# Altium schematic sheet FEZ-Duino-MIsc.SchDoc(FEZ-Duino-MIsc)
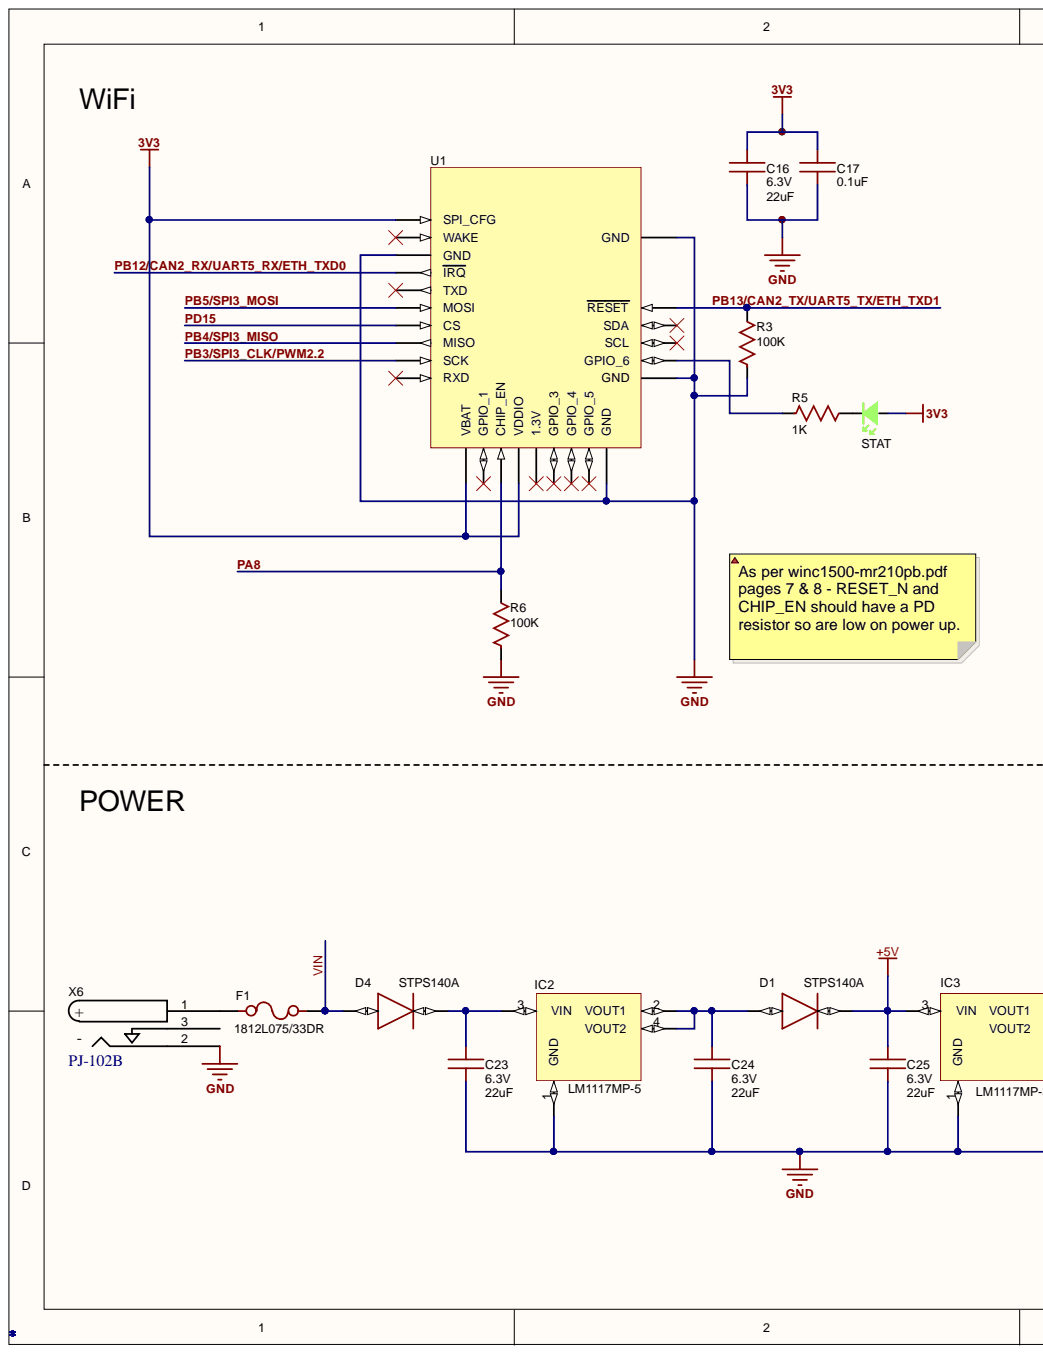
[diagram p0: FEZ-Duino-MIsc.SchDoc, left]
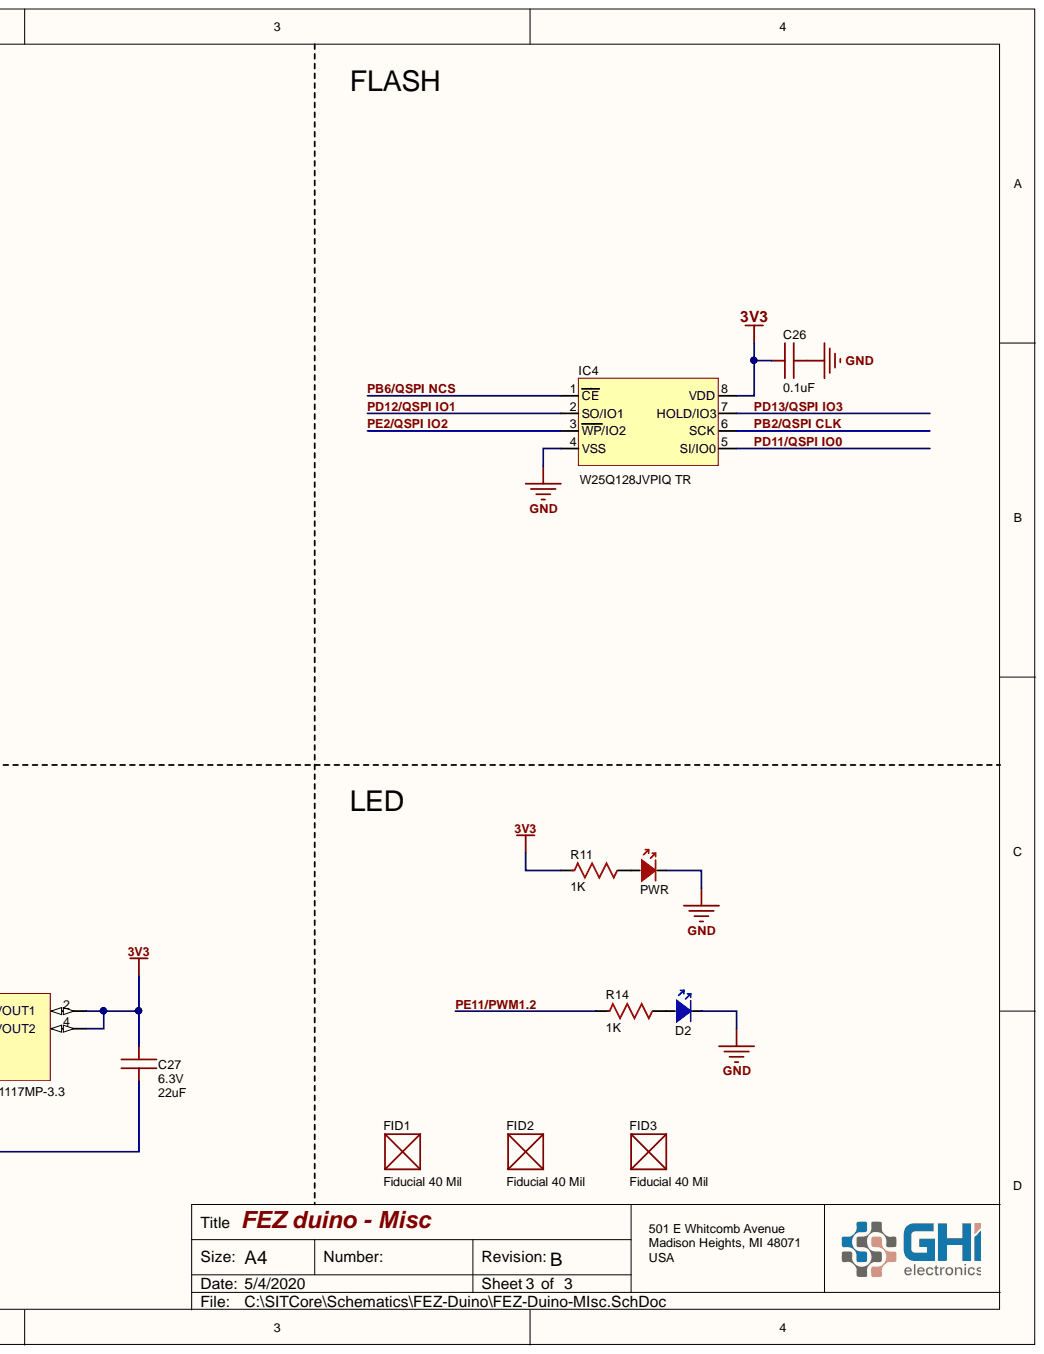
[diagram p1: FEZ-Duino-MIsc.SchDoc, right]

## Parts
C16  value 22uF  Voltage: 6.3V  [C0805 L]  (hidden comment: 0805 Cap 22uF)
C17  value 0.1uF  [C0603 (1608 Metric)]  (hidden comment: 0603 Cap 0.1uF)
C23  value 22uF  Voltage: 6.3V  [C0805 L]  (hidden comment: 0805 Cap 22uF)
C24  value 22uF  Voltage: 6.3V  [C0805 L]  (hidden comment: 0805 Cap 22uF)
C25  value 22uF  Voltage: 6.3V  [C0805 L]  (hidden comment: 0805 Cap 22uF)
C26  value 0.1uF  [C0603 (1608 Metric)]  (hidden comment: 0603 Cap 0.1uF)
C27  value 22uF  Voltage: 6.3V  [C0805 L]  (hidden comment: 0805 Cap 22uF)
D1  STPS140A  [DIODE_SMA]
D2  0603 LED Blue  [0603 LED Blue]  (value not drawn)
D4  STPS140A  [DIODE_SMA]
F1  value 1812L075/33DR  [1812 Fuse]
FID1  Fiducial 40 Mil  [Fiducial 40 Mil]  (no BOM)
FID2  Fiducial 40 Mil  [Fiducial 40 Mil]  (no BOM)
FID3  Fiducial 40 Mil  [Fiducial 40 Mil]  (no BOM)
IC2  value LM1117MP-5  [SOT223]
IC3  value LM1117MP-3.3  [SOT223]
IC4  value W25Q128JVPIQ TR  [WSON 8 6x5mm]  (hidden comment: FLASH - NOR Memory IC 128Mb)
PWR  0603 LED Red  [0603 LED Red]  (value not drawn)
R3  value 100K  [R0603 (1608 Metric)]  (hidden comment: 0603 Res 100K)
R5  value 1K  [R0603 (1608 Metric)]  (hidden comment: 0603 1K)
R6  value 100K  [R0603 (1608 Metric)]  (hidden comment: 0603 Res 100K)
R11  value 1K  [R0603 (1608 Metric)]  (hidden comment: 0603 1K)
R14  value 1K  [R0603 (1608 Metric)]  (hidden comment: 0603 1K)
STAT  0603 LED Green  [0603 LED Green]  (value not drawn)
U1  ATWINC1500_MR210PB  [ATWINC1500_MR210PA]  (value not drawn)
X6  PJ-102B  [PJ-102B Power Connector]

## Nets
3V3: C16.2 C17.2 C26.1 C27.2 IC3.2(VOUT1) IC3.4(VOUT2) IC4.8(VDD) R11.1 STAT.2 U1.J10(SPI_CFG) U1.J20(VBAT) U1.J23(VDDIO)
+5V: C25.2 D1.K IC3.3(VIN)
GND: C16.1 C17.1 C23.1 C24.1 C25.1 C26.2 C27.1 D2.1 IC2.1(GND) IC3.1(GND) IC4.4(VSS) PWR.1 R3.1 R6.1 U1.J9(GND) U1.J12(GND) U1.J28(GND) U1.J29(GND) X6.2
PA8: R6.2 U1.J22(CHIP_EN)
PB2/QSPI CLK: IC4.6(SCK)
PB3/SPI3_CLK/PWM2.2: U1.J18(SCK)
PB4/SPI3_MISO: U1.J17(MISO)
PB5/SPI3_MOSI: U1.J15(MOSI)
PB6/QSPI NCS: IC4.1(CE)
PB12/CAN2_RX/UART5_RX/ETH_TXD0: U1.J13(IRQ)
PB13/CAN2_TX/UART5_TX/ETH_TXD1: R3.2 U1.J4(RESET)
PD11/QSPI IO0: IC4.5(SI/IO0)
PD12/QSPI IO1: IC4.2(SO/IO1)
PD13/QSPI IO3: IC4.7(HOLD/IO3)
PD15: U1.J16(CS)
PE2/QSPI IO2: IC4.3(WP/IO2)
PE11/PWM1.2: R14.1
VIN: D4.A F1.2
NetC23_2: C23.2 D4.K IC2.3(VIN)
NetC24_2: C24.2 D1.A IC2.2(VOUT1) IC2.4(VOUT2)
NetD2_2: D2.2 R14.2
NetF1_1: F1.1 X6.1
NetPWR_2: PWR.2 R11.2
NetR5_1: R5.1 U1.J1(GPIO_6)
NetR5_2: R5.2 STAT.1

Not connected: U1 J2(SCL) J3(SDA) J11(WAKE) J14(TXD) J19(RXD) J21(GPIO_1) J24(1.3V) J25(GPIO_3) J26(GPIO_4) J27(GPIO_5); X6 3

## Library data of the parts
C16 C23-C25 C27 = 0805 Cap 22uF: 22uF ±20% 6.3V X5R 0805 Multilayer Ceramic Capacitors MLCC - SMD/SMT RoHS; Mfr.Part #: CL21A226MQCLRNC; Tolerance: ±20%; Package: 0805; LCSC Part #: C307530; Component Kind: Standard; Category: Ceramic; Capacitance: 22uF; Library Name: GHI-Passives.SchLib
C17 C26 = 0603 Cap 0.1uF: 0.1uF ±5% 50V X7R 0603 Multilayer Ceramic Capacitors MLCC - SMD/SMT RoHS; Mfr.Part #: CL10B104JB8NNNC; Voltage: 50V; Tolerance: ±5%; Package: 0603; LCSC Part #: C24452; Digikey: 1276-1033-1-ND; Component Kind: Standard; Category: Ceramic; Capacitance: 0.1uF; Library Name: GHI-Passives.SchLib
D1 D4 = DIODE_SMA: SymbolName_1: DIODE; GateName_1: G$1; Value: STPS140A; DeviceSetName: DIODE_SMA; Component Kind: Standard; LibraryName: GHI; Library Name: GHI.SchLib
D2 = 0603 LED Blue: Blue 468nm 0603 Light Emitting Diodes (LED) RoHS; Mfr.Part #: LTST-C193TBKT-5A; Manufacturer: Lite-On; Value: 0603 LED Blue; Package: 0603; LCSC Part #: C125103; Component Kind: Standard; Color: Blue; Library Name: GHI-Opto.SchLib
F1 = FUSE 33V 750MA 1812: Polymeric PTC Resettable Fuse 33V 750mA Ih Surface Mount 1812 (4532 Metric), Concave; Manufacturer: Littelfuse Inc.; Voltage - Max: PTC RESET FUSE 33V 750MA 1812; Current - Trip (It): 1.5A; Package: 1812; Mfr.Part #: 1812L075/33DR; LCSC Part #: C151170; Component Kind: Standard; : FUSE 33V 750MA 1812; Library Name: GHI-Passives.SchLib
IC2 = LM1117MPX-5.0: IC REG LIN 5V 800MA SOT223-4; SymbolName_1: LM1117; GateName_1: G$1; DeviceSetName: LM1117; Component Kind: Standard; : LM1117MPX-5.0; LibraryName: GHI; Library Name: GHI.SchLib
IC3 = LM1117MPX-3.3: IC REG LIN 3.3V 800MA SOT223-4; SymbolName_1: LM1117; GateName_1: G$1; DeviceSetName: LM1117; Component Kind: Standard; : LIN 3.3V; : LM1117MPX-3.3; LibraryName: GHI; Library Name: GHI.SchLib
IC4 = W25Q128JVPIQ: PartNo: W25Q128JVPIQ TR; Package: 8-WDFN Exposed Pad; LCSC Part No: C190862; Digikey Part No: W25Q128JVPIQCT-ND; Description: FLASH - NOR Memory IC 128Mb; Component Kind: Standard; Library Name: GHI.SchLib
PWR = 0603 LED Red: Red 631nm 0603 Light Emitting Diodes (LED) RoHS; Mfr.Part #: LTST-C193KRKT-5A; Manufacturer: Lite-On; Value: 0603 LED Red; Package: 0603; LCSC Part #: C157741; Component Kind: Standard; Color: Red; Library Name: GHI-Opto.SchLib
R3 R6 = 0603 Res 100K: 100K ±1% 1/10W ±100ppm/℃ 0603 Chip Resistor - Surface Mount RoHS; Mfr.Part #: RC0603FR-07100KL; Manufacturer: YAGEO; Voltage Rating: *V; Resistance Tolerance: ±1%; Resistance: 100K; Power Rating: 1/10W; Package: 0603; LCSC Part #: C14675; Component Kind: Standard; Library Name: GHI-Passives.SchLib
R5 R11 R14 = 0603 Res 1K: 1K ±5% 1/10W ±100ppm/℃ 0603 Chip Resistor - Surface Mount RoHS; Mfr.Part #: WR06X102 JTL; Manufacturer: Walsin Tech Corp; Voltage Rating: *V; Resistance Tolerance: ±5%; Resistance: 1K; Power Rating: 1/10W; Package: 0603; LCSC Part #: C384295; Component Kind: Standard; Library Name: GHI-Passives.SchLib
STAT = 0603 LED Green: Green 567.5~576.5nm 0603 Light Emitting Diodes (LED) RoHS; Mfr.Part #: LTST-C193KGKT-5A; Manufacturer: Lite-On; Value: 0603 LED Green; Package: 0603; LCSC Part #: C125102; Component Kind: Standard; Color: Green; Library Name: GHI-Opto.SchLib
U1 = ATWINC1500_MR210PA: ATWINC1500_MR210PA wifi module; Value: ATWINC1500-MR210UB1954; PartNo: ATWINC1500-MR210UB1954; Component Kind: Standard; Library Name: GHI.SchLib
X6 = PJ-102B: Component Kind: Standard; Library Name: GHI.SchLib
FID1-FID3 = Fiducial 40 Mil: Library Name: GHI.SchLib
